# Altium schematic sheet Buzzer.SchDoc
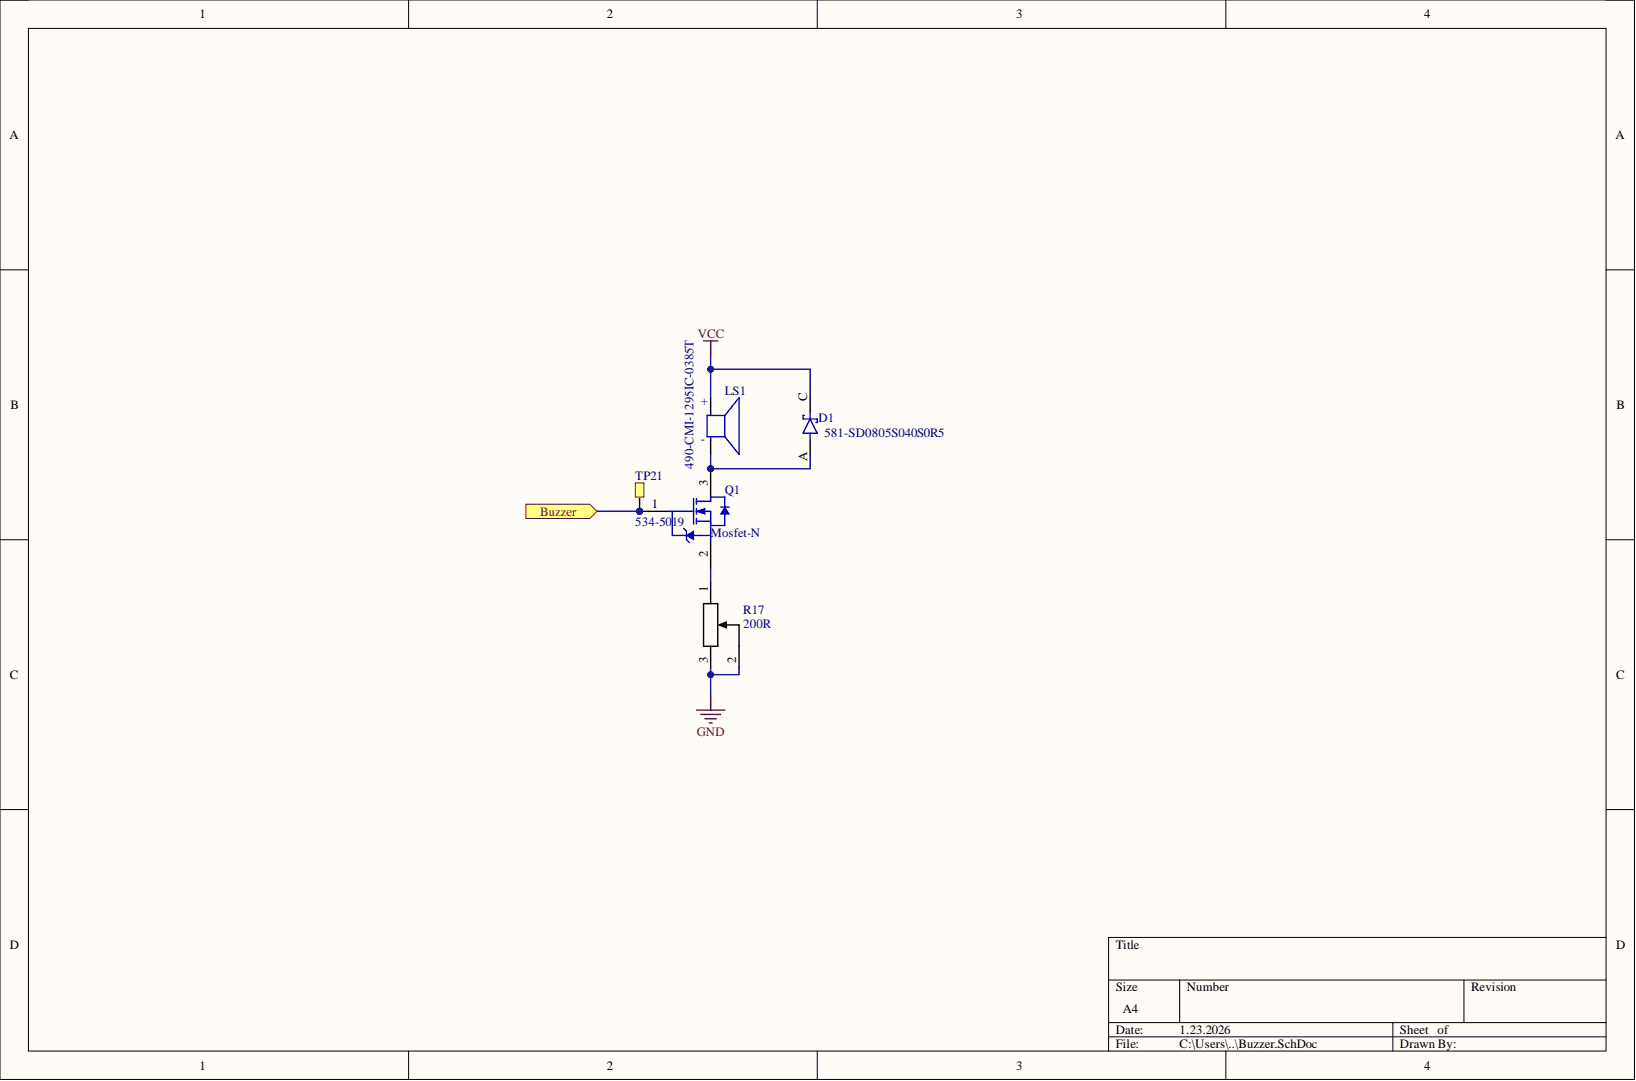
[diagram p0: Buzzer.SchDoc]

## Parts
D1  Supplier Part Number 1: 581-SD0805S040S0R5  [DIOSC130X200X100-2N]  (hidden comment: SD0805S040S0R1)
LS1  CMI-1295IC-0385T  Supplier Part Number 1: 490-CMI-1295IC-0385T  [CUI_CMI-1295IC-0385T]
Q1  Mosfet-N  [FAIR-SOT23-3_V]
R17  200R
TP21  5019  Supplier Part Number 1: 534-5019  [TESTPOINT_SMD]

## Ports
Buzzer

## Nets
GND: R17.2 R17.3
VCC: D1.C LS1.P
Buzzer: Q1.1 TP21.1 ; port Buzzer
NetD1_A: D1.A LS1.N Q1.3
NetQ1_2: Q1.2 R17.1

## Library data of the parts
D1 = SD0805S040S0R1: Diode Schottky 40V 100mA (DC) Surface Mount 0805 (2012 Metric); MF: AVX; Arrow_Electronics-Purchase-URL: https://snapeda.com/shop?store=Arrow+Electronics&id=809038; Availability: Good; Component Kind: Standard; DigiKey-Purchase-URL: https://snapeda.com/shop?store=DigiKey&id=809038; Mouser-Purchase-URL: https://snapeda.com/shop?store=Mouser&id=809038; MP: SD0805S040S0R1; Package: 0805 AVX; Price: None; SnapEDA_Link: https://www.snapeda.com/parts/SD0805S040S0R1/AVX/view-part/809038/?ref=snap; Supplier 1: Mouser; Library Name: 2008_SablierElectronique_SCHLIB.SchLib
LS1 = CMI-1295IC-0385T: 12 mm, 3 Vdc, 85 dB, Through Hole, Driving Circuit, Magnetic Audio Indicator Buzzer; MF: CUI Devices; Availability: Unavailable; Component Kind: Standard; MP: CMI-1295IC-0385T; Package: Package; Price: None; SnapEDA_Link: https://www.snapeda.com/parts/CMI-1295IC-0385T/CUI%20Devices/view-part/4413411/?ref=snap; Supplier 1: Mouser; Library Name: 2008_SablierElectronique_SCHLIB.SchLib
Q1 = NEMOS-GSD-3_D: Component Kind: Standard; Library Name: 2008_SablierElectronique_SCHLIB.SchLib
R17 = Pot_200R: Component Kind: Standard; Library Name: Schlib1.SchLib; Value: 200R
TP21 = TESTPOINT: Test point smd; Component Kind: Standard; Supplier 1: Mouser; Library Name: 2008_SablierElectronique_SCHLIB.SchLib
